# Altium schematic sheet TSAL_Light.SchDoc
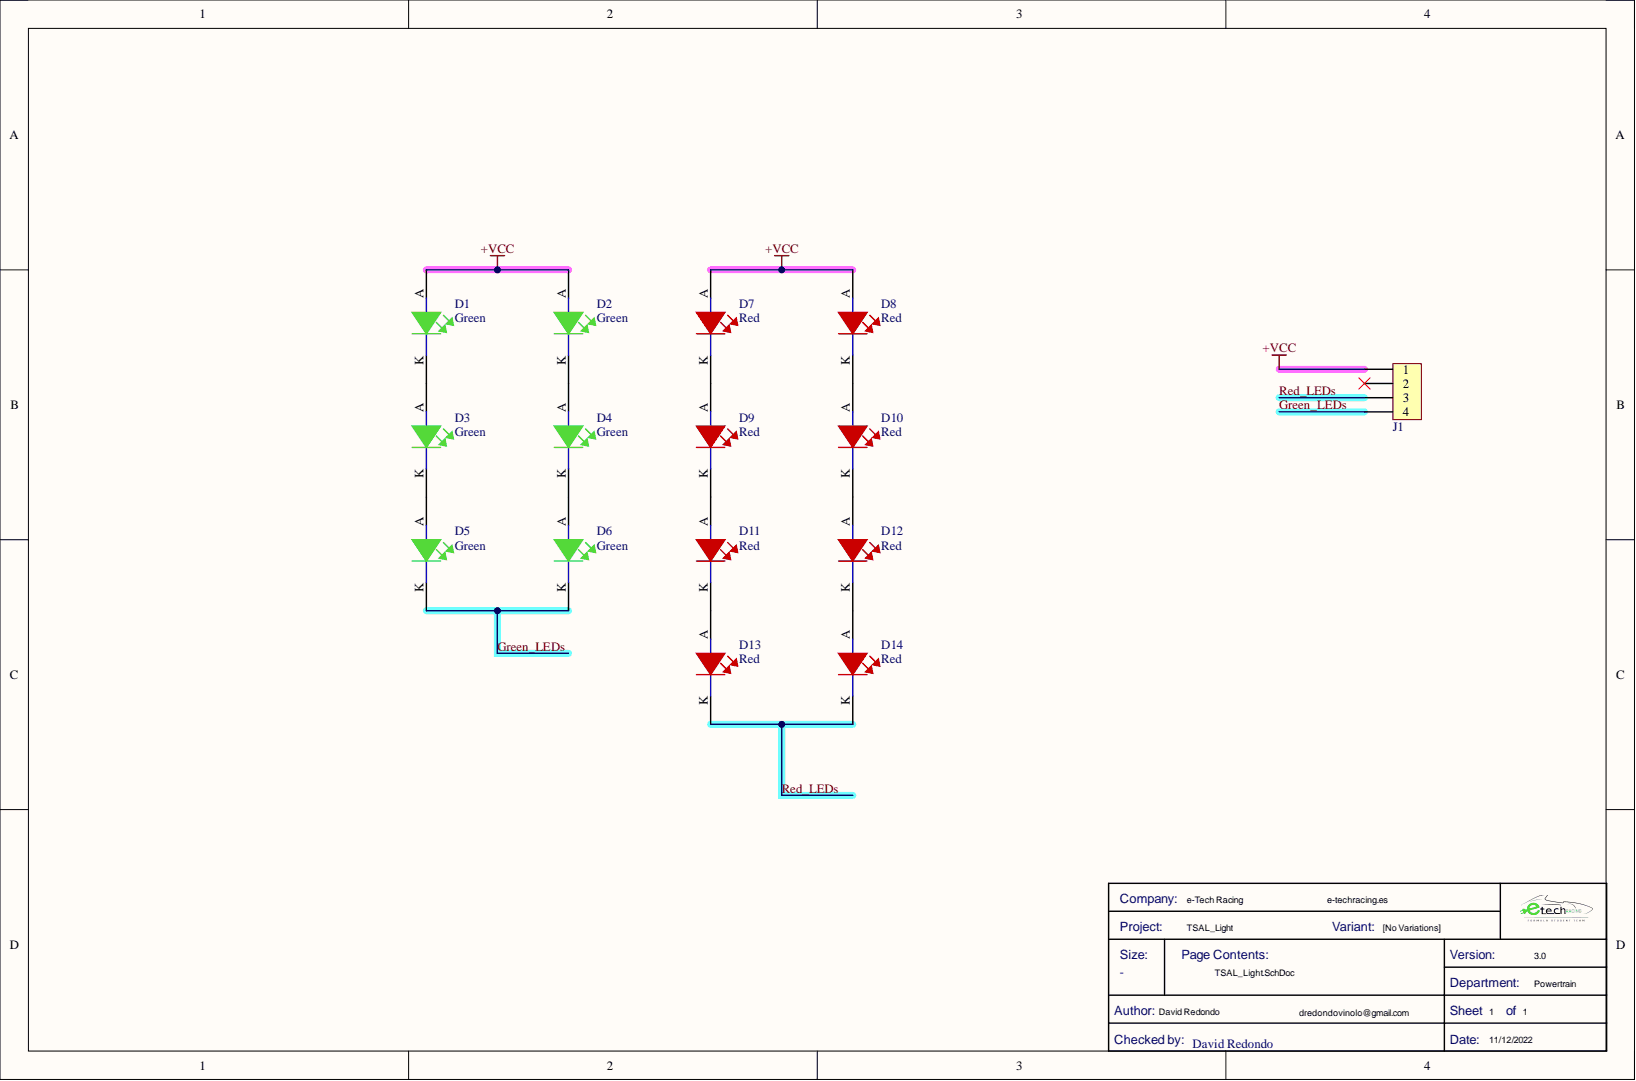
[diagram p0: TSAL_Light.SchDoc]

## Parts
D1  Color: Green  [LED_3535_WE]  (hidden comment: 150353GS74500)
D2  Color: Green  [LED_3535_WE]  (hidden comment: 150353GS74500)
D3  Color: Green  [LED_3535_WE]  (hidden comment: 150353GS74500)
D4  Color: Green  [LED_3535_WE]  (hidden comment: 150353GS74500)
D5  Color: Green  [LED_3535_WE]  (hidden comment: 150353GS74500)
D6  Color: Green  [LED_3535_WE]  (hidden comment: 150353GS74500)
D7  Color: Red  [LED_3535_WE]  (hidden comment: 150353RS74500)
D8  Color: Red  [LED_3535_WE]  (hidden comment: 150353RS74500)
D9  Color: Red  [LED_3535_WE]  (hidden comment: 150353RS74500)
D10  Color: Red  [LED_3535_WE]  (hidden comment: 150353RS74500)
D11  Color: Red  [LED_3535_WE]  (hidden comment: 150353RS74500)
D12  Color: Red  [LED_3535_WE]  (hidden comment: 150353RS74500)
D13  Color: Red  [LED_3535_WE]  (hidden comment: 150353RS74500)
D14  Color: Red  [LED_3535_WE]  (hidden comment: 150353RS74500)
J1  1053131204  [J_NanoFit_1x4]  (value not drawn)

## Nets
+VCC: D1.A D2.A D7.A D8.A J1.1
Green_LEDs: D5.K D6.K J1.4
Red_LEDs: D13.K D14.K J1.3
NetD1_K: D1.K D3.A
NetD2_K: D2.K D4.A
NetD3_K: D3.K D5.A
NetD4_K: D4.K D6.A
NetD7_K: D7.K D9.A
NetD8_K: D8.K D10.A
NetD9_K: D9.K D11.A
NetD10_K: D10.K D12.A
NetD11_K: D11.K D13.A
NetD12_K: D12.K D14.A

Not connected: J1 2

## Library data of the parts
D1-D6 = LEDGS-3535-85: High Brightness LED, WL-SMDC Series, 525 nm, Green, 85 lm, 350 mA; Manufacturer: Wurth Electronics; .Creator: GP; Forward Current: 1A; Forward Voltage: 3.4V; Luminous Flux: 85lm; Test Current: 350mA; Test Temperature: 25°C; Thermal Resistance of Package: 8°C/W; Viewing Angle: 125°; Wavelength: 525nm; Case/Package: SMD/SMT; Component Kind: Standard; ComponentLink1Description: http://www.farnell.com/datasheets/1886832.pdf?src-supplier=Farnell; ComponentLink1URL: http://www.farnell.com/datasheets/1886832.pdf?src-supplier=Farnell; ComponentLink2Description: http://www.farnell.com/datasheets/1886832.pdf?src-supplier=Element14; ComponentLink2URL: http://www.farnell.com/datasheets/1886832.pdf?src-supplier=Element14; ComponentLink3Description: Where buy it; ComponentLink3URL: https://www.we-online.com/catalog/en/WL-SMDC?sq=150353rs74500&sp=https%3A%2F%2Fwww.we-online.com%2Fweb%2Fen%2Fwuerth_elektronik%2Fsearchpage.php%3Fsearch%3D150353rs74500#150353GS74500; Library Name: Semiconductor.SchLib; Manufacturer Part Number: 150353GS74500; Max Current Rating: 1A; Mount: Surface Mount; Packaging: Cut Tape; Pricing 1: 1=3,24000, 50=2,85700, 100=2,34700, 500=2,08000 (USD); REACH SVHC: Unknown; RoHS: Yes; RoHS Compliant: Yes; Supplier 1: Digi-Key; Supplier Part Number 1: 732-10350-1-ND
D7-D14 = LEDRS-3535-55: High Brightness Led, Wl-Smdc Series, 625 Nm, Red, 55 Lm, 350 Ma; Manufacturer: Wurth Electronics; .Creator: GP; Forward Current: 700mA; Forward Voltage: 2.2V; Luminous Flux: 55lm; Test Current: 350mA; Test Temperature: 25°C; Thermal Resistance of Package: 10°C/W; Viewing Angle: 125°; Wavelength: 625nm; Case/Package: SMD/SMT; Component Kind: Standard; ComponentLink1Description: http://www.farnell.com/datasheets/1886833.pdf?src-supplier=Farnell; ComponentLink1URL: http://www.farnell.com/datasheets/1886833.pdf?src-supplier=Farnell; ComponentLink2Description: http://www.farnell.com/datasheets/1886833.pdf?src-supplier=Element14; ComponentLink2URL: http://www.farnell.com/datasheets/1886833.pdf?src-supplier=Element14; ComponentLink3Description: Where buy it; ComponentLink3URL: https://www.we-online.com/catalog/en/WL-SMDC?sq=150353rs74500&sp=https%3A%2F%2Fwww.we-online.com%2Fweb%2Fen%2Fwuerth_elektronik%2Fsearchpage.php%3Fsearch%3D150353rs74500#150353RS74500; Library Name: Semiconductor.SchLib; Manufacturer Part Number: 150353RS74500; Max Current Rating: 700mA; Mount: Surface Mount; Packaging: Cut Tape; Pricing 1: 5=1,40500 (USD); REACH SVHC: Unknown; RoHS: Yes; RoHS Compliant: Yes; Stock 1: 1; Supplier 1: RSComponents; Supplier Part Number 1: 9008911
J1 = J_NanoFit_1x4: Nano-Fit Right Angle Header; Through Hole; 2.50mm; Single Row; 4 Circuit; Gold Plated; Manufacturer: Molex; Manufacturer Part Number: 105313-1204; .Creator: DO; Gender: Male; Category: Headers and Wire Housings; Color: Black; Component Kind: Standard; ComponentLink1Description: http://www.farnell.com/datasheets/2216599.pdf?src-supplier=Farnell; ComponentLink1URL: http://www.farnell.com/datasheets/2216599.pdf?src-supplier=Farnell; ComponentLink2Description: http://www.farnell.com/datasheets/2216599.pdf?src-supplier=Element14; ComponentLink2URL: http://www.farnell.com/datasheets/2216599.pdf?src-supplier=Element14; ComponentLink3Description: http://www.farnell.com/datasheets/2216599.pdf?src-supplier=Newark; ComponentLink3URL: http://www.farnell.com/datasheets/2216599.pdf?src-supplier=Newark; ComponentLink4Description: https://www.molex.com/pdm_docs/sd/1053131102_sd.pdf?src-supplier=Digi-Key; ComponentLink4URL: https://www.molex.com/pdm_docs/sd/1053131102_sd.pdf?src-supplier=Digi-Key; Contact Material: Brass; Contact Plating: Gold; Library Name: Electromechanical.SchLib; Mount: Through Hole; Number of Rows: 1; Pricing 1: 10=1,14100, 50=0,65100, 100=0,63400, 250=0,61700, 500=0,60100 (GBP); REACH SVHC: Unknown; RoHS: Yes; RoHS Compliant: Yes; Stock 1: 286; Supplier 1: RSComponents; Supplier Part Number 1: 1225085; Termination: Through Hole
